# Altium schematic sheet ICY40_A02_Power.SchDoc
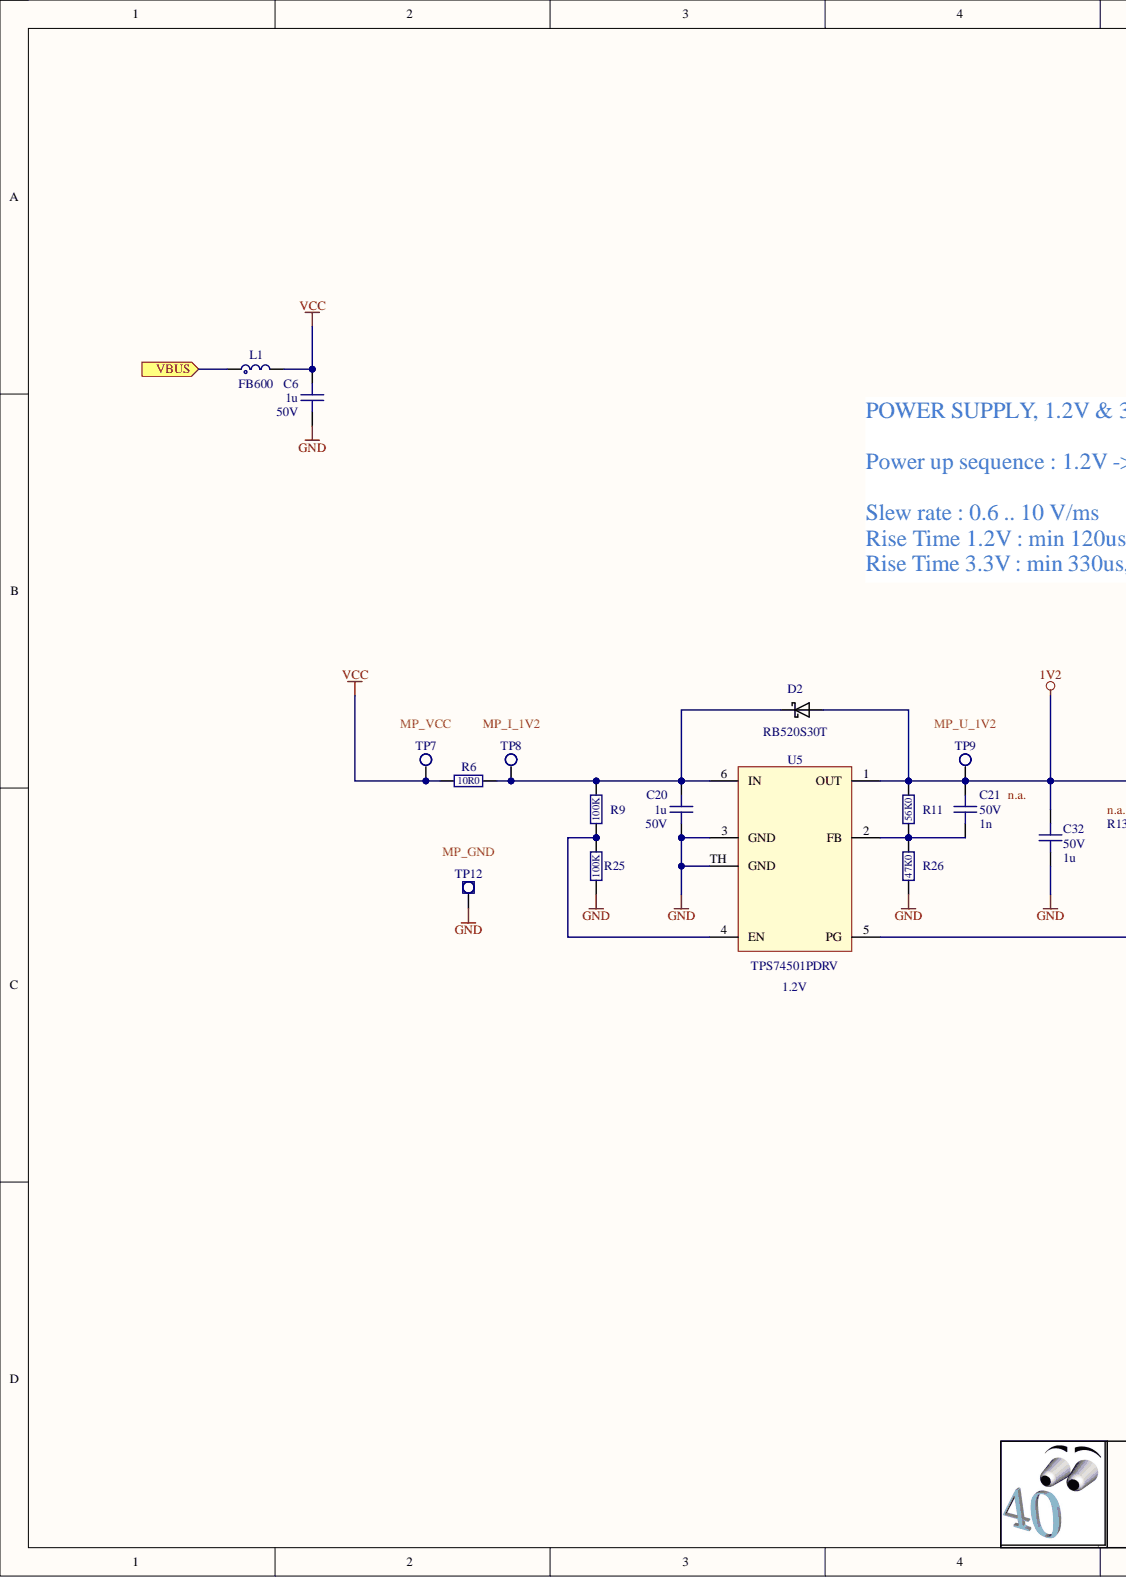
[diagram p0: ICY40_A02_Power.SchDoc, left]
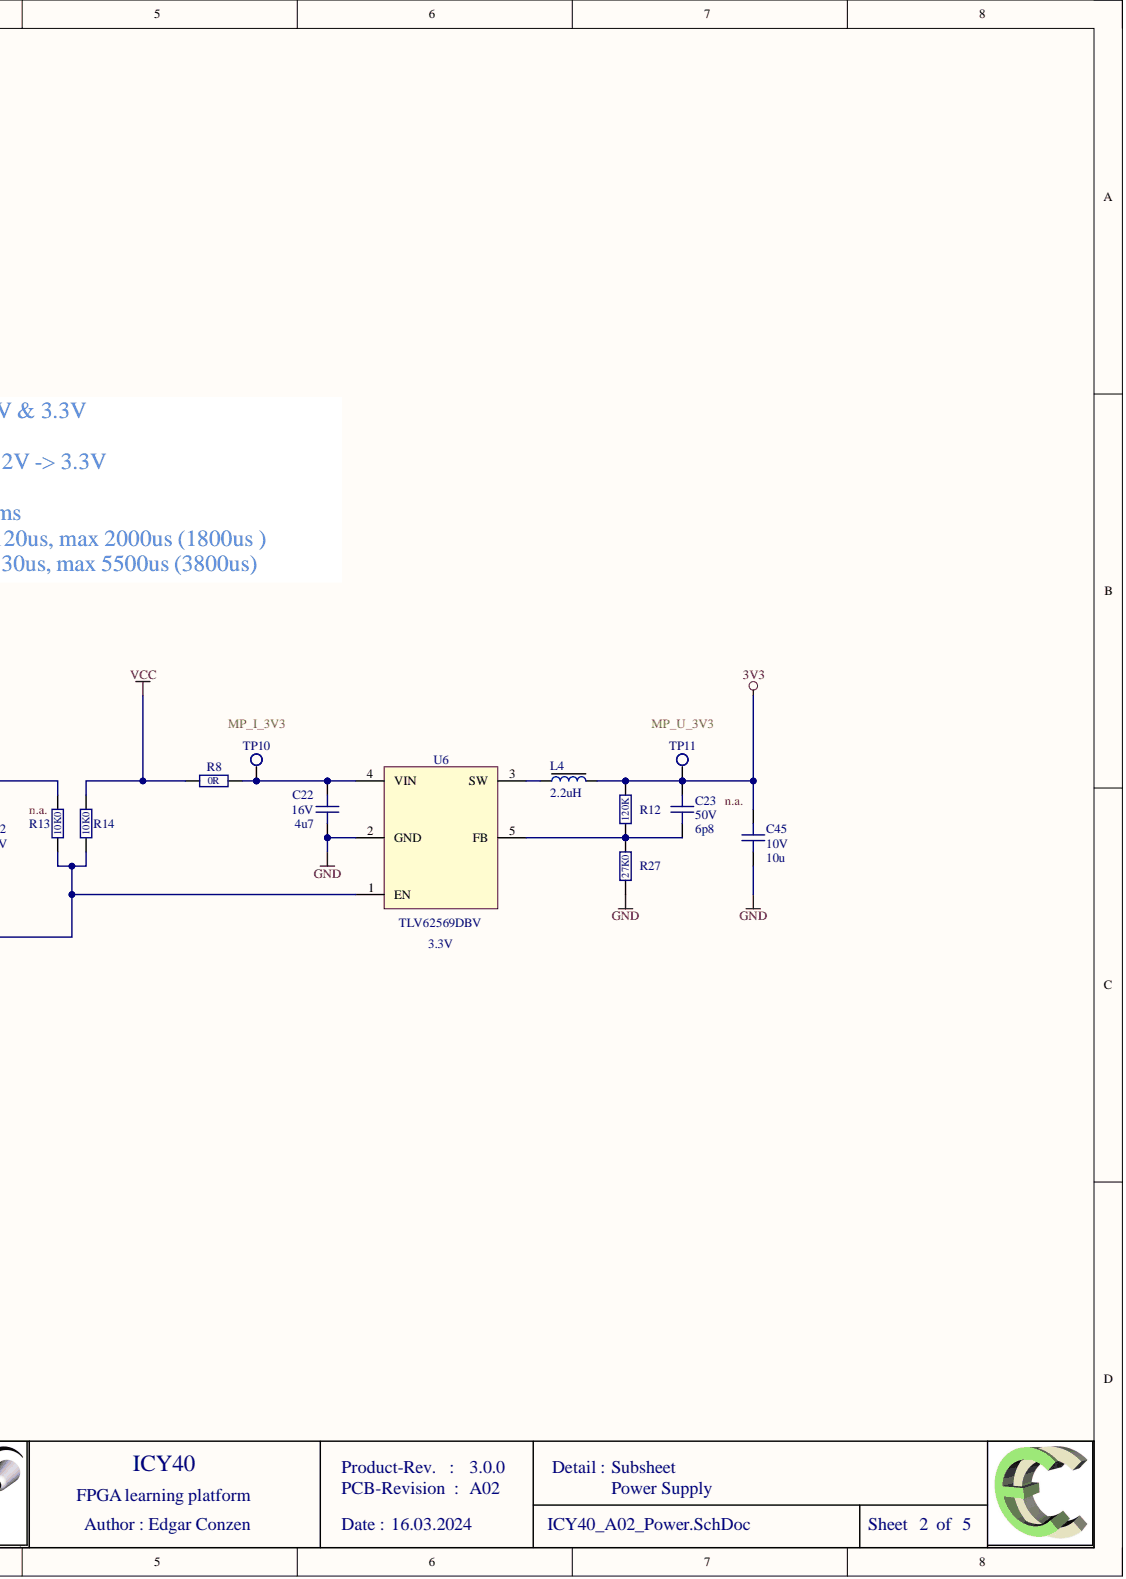
[diagram p1: ICY40_A02_Power.SchDoc, right]

## Parts
C6  1u  Voltage: 50V  [C_SMD_0603]
C20  1u  Voltage: 50V  [C_SMD_0603]
C21  1n  ESR: n.a.  Voltage: 50V  [C_SMD_0603]
C22  4u7  Voltage: 16V  [C_SMD_0603]
C23  6p8  Voltage: 50V  [C_SMD_0603]
C32  1u  Voltage: 50V  [C_SMD_0603]
C45  10u  ESR: n.a.  Voltage: 10V  [C_SMD_0603]
D2  RB520S30T  [SOD-523]
L1  FB600  [FB_SMD_0603]
L4  2.2uH  [L_2520-W]
R6  10R0  [R_SMD_0603]
R8  0R  [R_SMD_0603]
R9  100K  [R_SMD_0603]
R11  56K0  [R_SMD_0603]
R12  120K  [R_SMD_0603]
R13  10K0  [R_SMD_0603]
R14  10K0  [R_SMD_0603]
R25  100K  [R_SMD_0603]
R26  47K0  [R_SMD_0603]
R27  27K0  [R_SMD_0603]
TP7  5V  [TP_1.6_1.0]  (value not drawn)  (no BOM)
TP8  1I2  [TP_1.6_1.0]  (value not drawn)  (no BOM)
TP9  1V2  [TP_1.6_1.0]  (value not drawn)  (no BOM)
TP10  3I3  [TP_1.6_1.0]  (value not drawn)  (no BOM)
TP11  3V3  [TP_1.6_1.0]  (value not drawn)  (no BOM)
TP12  GND  [TP_1.6_1.0_R]  (no BOM)
U5  TPS74501PDRV  value 1.2V  [WSON6_2x2]
U6  TLV62569DBV  value 3.3V  [SOT23-5]

## Ports
VBUS

## Nets
1V2: C21.1 C32.1 D2.2 R11.1 R13.2 TP9.1 U5.1(OUT)
3V3: C23.1 C45.1 L4.2 R12.1 TP11.1
GND: C6.2 C20.2 C22.2 C32.2 C45.2 R25.2 R26.2 R27.2 TP12.1 U5.3(GND) U5.TH(GND) U6.2(GND)
VCC: C6.1 L1.2 R6.1 R8.1 R14.2 TP7.1
VBUS: L1.1 ; port VBUS
NetC20_1: C20.1 D2.1 R6.2 R9.1 TP8.1 U5.6(IN)
NetC21_2: C21.2 R11.2 R26.1 U5.2(FB)
NetC22_1: C22.1 R8.2 TP10.1 U6.4(VIN)
NetC23_2: C23.2 R12.2 R27.1 U6.5(FB)
NetL4_1: L4.1 U6.3(SW)
NetR9_2: R9.2 R25.1 U5.4(EN)
NetR13_1: R13.1 R14.1 U5.5(PG) U6.1(EN)

## Library data of the parts
C6 C20 C32 = C-CER_0603_1u_X7R_50V_10%: Non-Polarized Capacitor; IM_PARTNR: 00005053; ASSY_ALT: ---; ASSY_STEP: SMD1; ASSY_TYPE: SMD; COMPLIANCE: RoHS; Database Table Name: C-CER; ESR: n.a.; LIB_STATE: Preliminary; MAT: X7R; MFG_NAME: various…; NB: B; TCC: 15%; TEMP_STORE: -55°C to +85°C; TOL: 10%; TYPE: C; VALUE: 1000000; ASSY_NOTE: ---; CASE: 0603; Component Kind: Standard; ComponentLink1Description: Datasheet; ComponentLink3Description: Manufacturer Link; D-ID: C-CER_0603_1u_X7R_50V_10%; DISPVAL: 1u; Library Name: C.DbLib; MFG_PARTNR: various…; MSL: 1; NOTES: ---; TEMP_USE: -55°C to +85°C; USAGE: ---
C21 = C-CER_0603_1n_C0G_50V_5%: Non-Polarized Capacitor; IM_PARTNR: 00001096; ASSY_ALT: ---; ASSY_STEP: SMD1; ASSY_TYPE: SMD; COMPLIANCE: RoHS; Database Table Name: C-CER; LIB_STATE: Preliminary; MAT: C0G; MFG_NAME: various…; NB: B; TCC: 30ppm/K; TEMP_STORE: -55°C to +125°C; TOL: 5%; TYPE: C; VALUE: 1000; ASSY_NOTE: ---; CASE: 0603; Component Kind: Standard; ComponentLink1Description: Datasheet; ComponentLink3Description: Manufacturer Link; D-ID: C-CER_0603_1n_C0G_50V_5%; DISPVAL: 1n; Library Name: C.DbLib; MFG_PARTNR: various…; MSL: 1; NOTES: ---; TEMP_USE: -55°C to +125°C; USAGE: ---
C22 = C-CER_0603_4u7_X5R_16V_10%: Non-Polarized Capacitor; IM_PARTNR: TDB; ASSY_ALT: ---; ASSY_STEP: SMD1; ASSY_TYPE: SMD; COMPLIANCE: RoHS; Database Table Name: C-CER; ESR: n.a.; LIB_STATE: Preliminary; MAT: X5R; MFG_NAME: various…; NB: B; TCC: 15%; TEMP_STORE: -55°C to +85°C; TOL: 10%; TYPE: C; VALUE: 4700000; ASSY_NOTE: ---; CASE: 0603; Component Kind: Standard; ComponentLink1Description: Datasheet; ComponentLink3Description: Manufacturer Link; D-ID: C-CER_0603_4u7_X5R_16V_10%; DISPVAL: 4u7; Library Name: C.DbLib; MFG_PARTNR: various…; MSL: 1; NOTES: ---; TEMP_USE: -55°C to +85°C; USAGE: ---
C23 = C-CER_0603_6p8_C0G_50V_0.1P: Non-Polarized Capacitor; IM_PARTNR: TBD; ASSY_ALT: ---; ASSY_STEP: SMD1; ASSY_TYPE: SMD; COMPLIANCE: RoHS; Database Table Name: C-CER; ESR: n.a.; LIB_STATE: Preliminary; MAT: C0G; MFG_NAME: various…; NB: B; TCC: 30ppm/K; TEMP_STORE: -55°C to +125°C; TOL: 0.1pF; TYPE: C; VALUE: 6,8; ASSY_NOTE: ---; CASE: 0603; Component Kind: Standard; ComponentLink1Description: Datasheet; ComponentLink3Description: Manufacturer Link; D-ID: C-CER_0603_6p8_C0G_50V_0.1P; DISPVAL: 6p8; Library Name: C.DbLib; MFG_PARTNR: various…; MSL: 1; NOTES: ---; TEMP_USE: -55°C to +125°C; USAGE: ---
C45 = C-CER_0603_10u_X5R_10V_10%: Non-Polarized Capacitor; IM_PARTNR: 00005407; ASSY_ALT: ---; ASSY_STEP: SMD1; ASSY_TYPE: SMD; COMPLIANCE: RoHS; Database Table Name: C-CER; LIB_STATE: Preliminary; MAT: X5R; MFG_NAME: various…; NB: B; TCC: 15%; TEMP_STORE: -55°C to +85°C; TOL: 10%; TYPE: C; VALUE: 10000000; ASSY_NOTE: ---; CASE: 0603; Component Kind: Standard; ComponentLink1Description: Datasheet; ComponentLink3Description: Manufacturer Link; D-ID: C-CER_0603_10u_X5R_10V_10%; DISPVAL: 10u; Library Name: C.DbLib; MFG_PARTNR: various…; MSL: 1; NOTES: ---; TEMP_USE: -55°C to +85°C; USAGE: ---
D2 = RB520S30T: Switching diode, High speed , 30V, 200mA; IM_PARTNR: tbd; ASSY_TYPE: SMD; MFG_NAME: On Semiconductor; TYPE: Switching-Diode; CASE: SOD-523; Component Kind: Standard; ComponentLink1Description: Datasheet; ComponentLink2Description: Soldering Profile; ComponentLink3Description: Manufacturer Link; D-ID: RB520S30T; DISPVAL: RB520S30T; MFG_PARTNR: RB520S30T; Library Name: Icy40.SchLib
L1 = L_0603_BEAD_600R@100MHz_500mA: Ferrite Bead 0603 500mA 600R@100MHz Murata BLM18KG601BH1D; IM_PARTNR: 00005306; ASSY_ALT: ---; ASSY_STEP: SMD1; ASSY_TYPE: SMD; ASSY_NOTE: ---; CASE: 0603; Component Kind: Standard; ComponentLink1Description: Datasheet; ComponentLink1URL: http://svn.ib/altium/ds/_inductor/Murata-BLM18KG601BH1D.pdf; D-ID: L_0603_BEAD_600R@100MHz_500mA; Library Name: L.SchLib; MFG_NAME: Murata; MFG_PARTNR: BLM18KG601BH1D; Supplier 1: Digi-Key; Supplier Part Number 1: 490-14032-1-ND; Value: FB600
L4 = L-POW_2.2U_TaiyoYuden_MAMK2520T2R2M-W: L2520 SMD-Speicherdrossel 2.2uH, 1.9A, 110mR; IM_PARTNR: 00005328; ASSY_ALT: ---; ASSY_STEP: SMD1; ASSY_TYPE: SMD; D-ID: L-POW_2.2U_TaioYuden_MAMK2520T2R2M; VALUE: 2,2; ASSY_NOTE: ---; CASE: 2520; Component Kind: Standard; ComponentLink1Description: Datasheet; ComponentLink1URL: http://svn.ib/altium/ds/_inductor/TaiyoYuden_Inductor_wound10_e.pdf; MFG_NAME: TaiyoYuden; MFG_PARTNR: MAMK2520T2R2M; Supplier 1: Digi-Key; Supplier Part Number 1: 587-3226-1-ND; Library Name: Icy40.SchLib
R6 = R_0603_10R0_1%_TCR100_0.1W: Resistor; IM_PARTNR: 00002534; ASSY_ALT: ---; ASSY_STEP: SMD1; ASSY_TYPE: SMD; COMPLIANCE: ROHS; Database Table Name: R; MFG_NAME: various…; NB: B; POWER: 0.1W; TCR: 100; TEMP_STORE: n/A; TEMP_USE: -55° .. +155°C; TOL: 1%; VALUE: 10; VOLTAGE: 75V; ASSY_NOTE: ---; CASE: 0603; Component Kind: Standard; ComponentLink1Description: Datasheet; ComponentLink2Description: Solder Profile; ComponentLink3Description: Manufacturer Link; D-ID: R_0603_10R0_1%_TCR100_0.1W; DISPVAL: 10R0; Library Name: R.DbLib; LIFECYCLE: Active; MFG_PARTNR: various…; MSL: 1; TYPE: R
R8 = R_0603_0R_1A: Resistor; IM_PARTNR: 00000961; ASSY_ALT: ---; ASSY_STEP: SMD1; ASSY_TYPE: SMD; COMPLIANCE: ROHS; Database Table Name: R; MFG_NAME: various…; NB: B; POWER: 1A; TCR: 100; TEMP_STORE: n/A; TEMP_USE: -55° .. +155°C; TOL: 1%; VALUE: 0; VOLTAGE: 75V; ASSY_NOTE: ---; CASE: 0603; Component Kind: Standard; ComponentLink1Description: Datasheet; ComponentLink2Description: Solder Profile; ComponentLink3Description: Manufacturer Link; D-ID: R_0603_0R_1A; DISPVAL: 0R; Library Name: R.DbLib; LIFECYCLE: Active; MFG_PARTNR: various…; MSL: 1; TYPE: R
R9 R25 = R_0603_100K_1%_TCR100_0.1W: Resistor; IM_PARTNR: 00001424; ASSY_ALT: ---; ASSY_STEP: SMD1; ASSY_TYPE: SMD; COMPLIANCE: ROHS; Database Table Name: R; MFG_NAME: various…; NB: B; POWER: 0.1W; TCR: 100; TEMP_STORE: n/A; TEMP_USE: -55° .. +155°C; TOL: 1%; VALUE: 100000; VOLTAGE: 75V; ASSY_NOTE: ---; CASE: 0603; Component Kind: Standard; ComponentLink1Description: Datasheet; ComponentLink2Description: Solder Profile; ComponentLink3Description: Manufacturer Link; D-ID: R_0603_100K_1%_TCR100_0.1W; DISPVAL: 100K; Library Name: R.DbLib; LIFECYCLE: Active; MFG_PARTNR: various…; MSL: 1; TYPE: R
R11 = R_0603_56K0_1%_TCR100_0.1W: Resistor; IM_PARTNR: 00001415; ASSY_ALT: ---; ASSY_STEP: SMD1; ASSY_TYPE: SMD; COMPLIANCE: ROHS; Database Table Name: R; MFG_NAME: various…; NB: B; POWER: 0.1W; TCR: 100; TEMP_STORE: n/A; TEMP_USE: -55° .. +155°C; TOL: 1%; VALUE: 56000; VOLTAGE: 75V; ASSY_NOTE: ---; CASE: 0603; Component Kind: Standard; ComponentLink1Description: Datasheet; ComponentLink2Description: Solder Profile; ComponentLink3Description: Manufacturer Link; D-ID: R_0603_56K0_1%_TCR100_0.1W; DISPVAL: 56K0; Library Name: R.DbLib; LIFECYCLE: Active; MFG_PARTNR: various…; MSL: 1; TYPE: R
R12 = R_0603_120K_1%_TCR100_0.1W: Resistor; IM_PARTNR: 00001920; ASSY_ALT: ---; ASSY_STEP: SMD1; ASSY_TYPE: SMD; COMPLIANCE: ROHS; Database Table Name: R; MFG_NAME: various…; NB: B; POWER: 0.1W; TCR: 100; TEMP_STORE: n/A; TEMP_USE: -55° .. +155°C; TOL: 1%; VALUE: 120000; VOLTAGE: 75V; ASSY_NOTE: ---; CASE: 0603; Component Kind: Standard; ComponentLink1Description: Datasheet; ComponentLink2Description: Solder Profile; ComponentLink3Description: Manufacturer Link; D-ID: R_0603_120K_1%_TCR100_0.1W; DISPVAL: 120K; Library Name: R.DbLib; LIFECYCLE: Active; MFG_PARTNR: various…; MSL: 1; TYPE: R
R13 R14 = R_0603_10K0_1%_TCR100_0.1W: Resistor; IM_PARTNR: 00001313; ASSY_ALT: ---; ASSY_STEP: SMD1; ASSY_TYPE: SMD; COMPLIANCE: ROHS; Database Table Name: R; MFG_NAME: various…; NB: B; POWER: 0.1W; TCR: 100; TEMP_STORE: n/A; TEMP_USE: -55° .. +155°C; TOL: 1%; VALUE: 10000; VOLTAGE: 75V; ASSY_NOTE: ---; CASE: 0603; Component Kind: Standard; ComponentLink1Description: Datasheet; ComponentLink2Description: Solder Profile; ComponentLink3Description: Manufacturer Link; D-ID: R_0603_10K0_1%_TCR100_0.1W; DISPVAL: 10K0; Library Name: R.DbLib; LIFECYCLE: Active; MFG_PARTNR: various…; MSL: 1; TYPE: R
R26 = R_0603_47K0_1%_TCR100_0.1W: Resistor; IM_PARTNR: 00002027; ASSY_ALT: ---; ASSY_STEP: SMD1; ASSY_TYPE: SMD; COMPLIANCE: ROHS; Database Table Name: R; MFG_NAME: various…; NB: B; POWER: 0.1W; TCR: 100; TEMP_STORE: n/A; TEMP_USE: -55° .. +155°C; TOL: 1%; VALUE: 47000; VOLTAGE: 75V; ASSY_NOTE: ---; CASE: 0603; Component Kind: Standard; ComponentLink1Description: Datasheet; ComponentLink2Description: Solder Profile; ComponentLink3Description: Manufacturer Link; D-ID: R_0603_47K0_1%_TCR100_0.1W; DISPVAL: 47K0; Library Name: R.DbLib; LIFECYCLE: Active; MFG_PARTNR: various…; MSL: 1; TYPE: R
R27 = R_0603_27K0_1%_TCR100_0.1W: Resistor; IM_PARTNR: 00002347; ASSY_ALT: ---; ASSY_STEP: SMD1; ASSY_TYPE: SMD; COMPLIANCE: ROHS; Database Table Name: R; MFG_NAME: various…; NB: B; POWER: 0.1W; TCR: 100; TEMP_STORE: n/A; TEMP_USE: -55° .. +155°C; TOL: 1%; VALUE: 27000; VOLTAGE: 75V; ASSY_NOTE: ---; CASE: 0603; Component Kind: Standard; ComponentLink1Description: Datasheet; ComponentLink2Description: Solder Profile; ComponentLink3Description: Manufacturer Link; D-ID: R_0603_27K0_1%_TCR100_0.1W; DISPVAL: 27K0; Library Name: R.DbLib; LIFECYCLE: Active; MFG_PARTNR: various…; MSL: 1; TYPE: R
TP7-TP11 = TP_1.5_0.9: Testpoint 1.5mm D=0.9mm; Library Name: Connector-Divers.SchLib
TP12 = TP_1.5_0.9_R: Testpoint 1.5mm Hole=0.9mm Square; Library Name: Connector-Divers.SchLib
U5 = TPS74501PDRV: LDO_Vin_1.5-6.0V_Vout_0.55V-5.5V_500mA_WSON6_2x2; IM_PARTNR: tbd; ASSY_NOTE: ---; ASSY_TYPE: SMD; MFG_NAME: TI; Component Kind: Standard; ComponentLink1Description: Datasheet; D-ID: TPS74501PDRV; MFG_PARTNR: TPS74501PDRV; Library Name: Icy40.SchLib
U6 = TLV62569DBV: SWREG_SyncBuck_Vin2.5-5.5V_2A_1.5MHZ_SOT23-5; IM_PARTNR: 00005426; ASSY_NOTE: ---; ASSY_TYPE: SMD; MFG_NAME: Texas Instruments; Component Kind: Standard; ComponentLink1Description: Datasheet; ComponentLink1URL: http://svn.ib/altium/ds/TI/TI_tlv62569_SyncBuck_5.5V_2A.pdf; D-ID: TLV62569DBV; MFG_PARTNR: TLV62569DBV; Library Name: Icy40.SchLib
